# Altium schematic sheet Knot_Thing_USB_Power.SchDoc
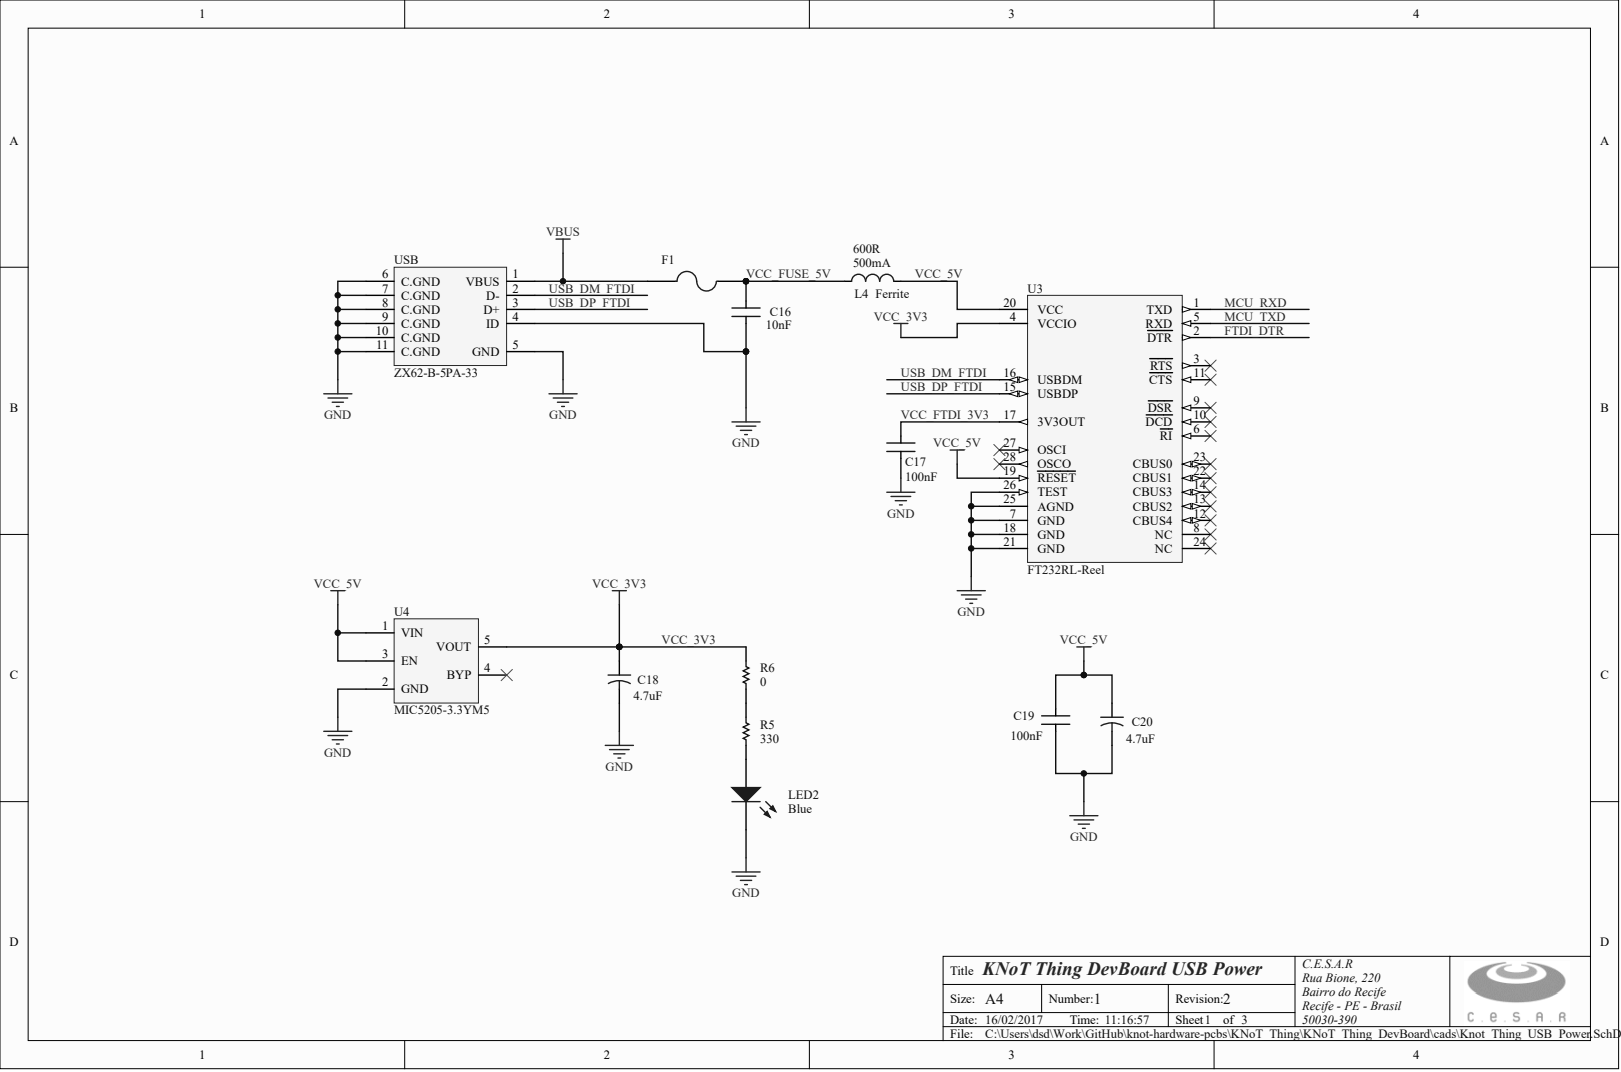
[diagram p0: Knot_Thing_USB_Power.SchDoc]

## Parts
C16  value 10nF  (hidden comment: Cap_0402)
C17  value 100nF  (hidden comment: Cap_0402)
C18  value 4.7uF  (hidden comment: Cap0603)
C19  value 100nF  (hidden comment: Cap_0402)
C20  value 4.7uF  (hidden comment: Cap0603)
F1  0402L050SLKR  (value not drawn)
L4  Ferrite  Res: 600R  Cur: 500mA
LED2  Color: Blue  (hidden comment: LED 0603)
R5  value 330  (hidden comment: RES_0402)
R6  value 0  (hidden comment: RES_0402)
U3  FT232RL-Reel
U4  MIC5205-3.3YM5
USB  ZX62-B-5PA-33

## Nets
GND: C16.1 C17.2 C18.2 C19.2 C20.2 LED2.2 U3.7(GND) U3.18(GND) U3.21(GND) U3.25(AGND) U3.26(TEST) U4.2(GND) USB.4(ID) USB.5(GND) USB.6(C.GND) USB.7(C.GND) USB.8(C.GND) USB.9(C.GND) USB.10(C.GND) USB.11(C.GND)
VBUS: F1.1 USB.1(VBUS)
FTDI_DTR: U3.2(DTR)
MCU_RXD: U3.1(TXD)
MCU_TXD: U3.5(RXD)
USB_DM_FTDI: U3.16(USBDM) USB.2(D-)
USB_DP_FTDI: U3.15(USBDP) USB.3(D+)
VCC_3V3: C18.1 R6.2 U3.4(VCCIO) U4.5(VOUT)
VCC_5V: C19.1 C20.1 L4.2 U3.19(RESET) U3.20(VCC) U4.1(VIN) U4.3(EN)
VCC_FTDI_3V3: C17.1 U3.17(3V3OUT)
VCC_FUSE_5V: C16.2 F1.2 L4.1
NetLED2_1: LED2.1 R5.1
NetR5_2: R5.2 R6.1

Not connected: U3 3(RTS) 6(RI) 8(NC) 9(DSR) 10(DCD) 11(CTS) 12(CBUS4) 13(CBUS2) 14(CBUS3) 22(CBUS1) 23(CBUS0) 24(NC) 27(OSCI) 28(OSCO); U4 4(BYP)

## Library data of the parts
U3 = (no symbol name): Manufacturer: FTDI; DatasheetVersion: ver 2.10, Mar-2012; PackageVersion: Mar-12; PackageReference: SSOP-28; PackageDescription: 28-Pin Small Outline Package 0.65 mm Pitch; PartNumber: FT232RL-Reel; ComponentLink1Description: Manufacturer URL; ComponentLink1URL: http://www.ftdichip.com/; ComponentLink2Description: Datasheet; ComponentLink2URL: http://www.ftdichip.com/Support/Documents/DataSheets/ICs/DS_FT232R.pdf; Supplier 1: Digi-Key; Supplier Part Number 1: 768-1007-6-ND
C16-C20 F1 L4 LED2 R5 R6 U4 USB = (no symbol name): Supplier 1: Digi-Key
  C16: Supplier Part Number 1: 311-1703-1-ND
  C17 C19: Supplier Part Number 1: 311-1731-1-ND
  C18 C20: Published: 23-Sep-2002; PackageDescription: Capacitor; 2 Leads; Supplier Part Number 1: 311-1453-1-ND; Publisher: Altium Limited
  F1: Supplier Part Number 1: F2772CT-ND
  L4: Supplier Part Number 1: 445-2166-1-ND
  LED2: Supplier Part Number 1: 754-1789-1-ND
  R5: Supplier Part Number 1: 311-330JRCT-ND
  R6: Supplier Part Number 1: 311-0.0JRTR-ND
  U4: Supplier Part Number 1: 576-1259-1-ND
  USB: Supplier Part Number 1: H125270CT-ND
